# Altium schematic sheet [01] - RS232.SchDoc("[01] - RS232")
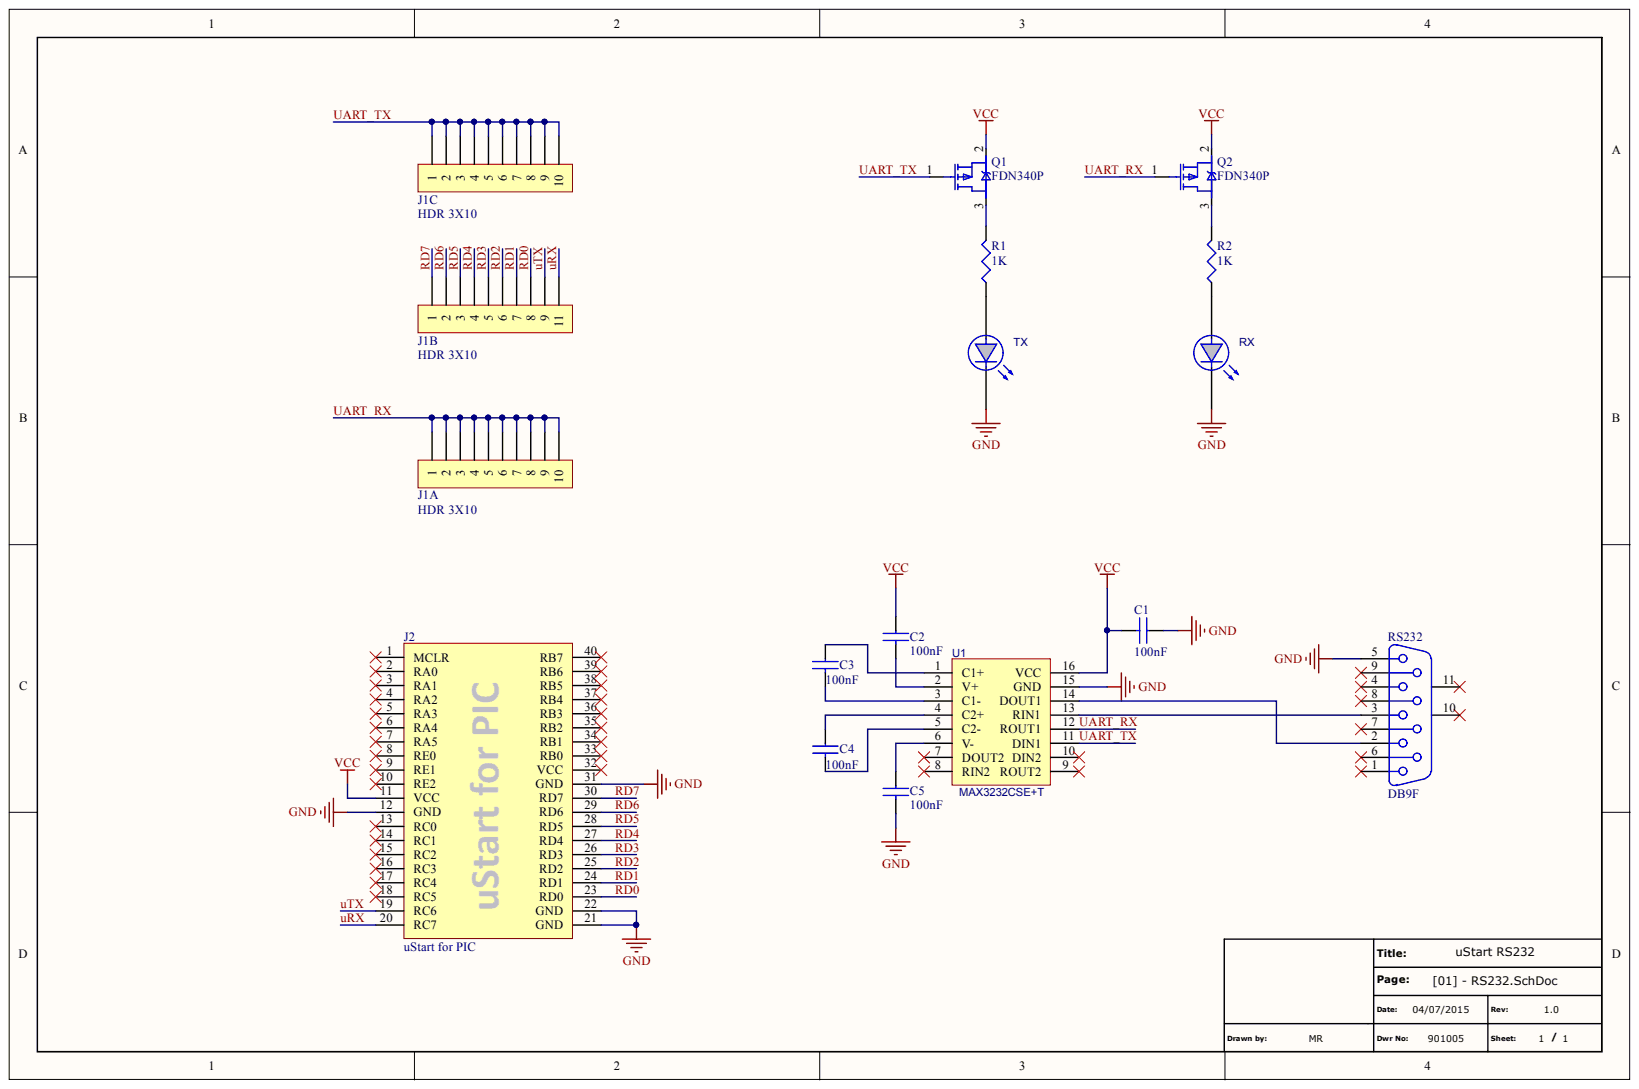
[diagram p0: [01] - RS232.SchDoc]

## Parts
C1  100nF  [C0603]
C2  100nF  [C0603]
C3  100nF  [C0603]
C4  100nF  [C0603]
C5  100nF  [C0603]
J1  HDR 3X10  [HDR 3X10 2.54MM]  (parts A,B,C)
J2  uStart for PIC  [SHIELD_uStart]  (value not drawn)
Q1  FDN340P  [SOT-23]
Q2  FDN340P  [SOT-23]
R1  1K  [R0805]
R2  1K  [R0805]
RS232  DB9F  [DB9_F_90]
RX  LEDSMD 0805  [LED_0805]  (value not drawn)
TX  LEDSMD 0805  [LED_0805]  (value not drawn)
U1  MAX3232CSE+T  [16-SOIC]

## Nets
GND: C1.1 C5.2 J2.12(GND) J2.21(GND) J2.22(GND) J2.31(GND) RS232.5 RX.2 TX.2 U1.15(GND)
VCC: C1.2 C2.1 J2.11(VCC) Q1.2 Q2.2 U1.16(VCC)
RD0: J1.18 J2.23(RD0)
RD1: J1.17 J2.24(RD1)
RD2: J1.16 J2.25(RD2)
RD3: J1.15 J2.26(RD3)
RD4: J1.14 J2.27(RD4)
RD5: J1.13 J2.28(RD5)
RD6: J1.12 J2.29(RD6)
RD7: J1.11 J2.30(RD7)
UART_RX: J1.1 J1.2 J1.3 J1.4 J1.5 J1.6 J1.7 J1.8 J1.9 J1.10 Q2.1 U1.12(ROUT1)
UART_TX: J1.21 J1.22 J1.23 J1.24 J1.25 J1.26 J1.27 J1.28 J1.29 J1.30 Q1.1 U1.11(DIN1)
URX: J1.20 J2.20(RC7)
UTX: J1.19 J2.19(RC6)
NetC2_2: C2.2 U1.2(V+)
NetC3_1: C3.1 U1.1(C1+)
NetC3_2: C3.2 U1.3(C1-)
NetC4_1: C4.1 U1.4(C2+)
NetC4_2: C4.2 U1.5(C2-)
NetC5_1: C5.1 U1.6(V-)
NetQ1_3: Q1.3 R1.2
NetQ2_3: Q2.3 R2.2
NetR1_1: R1.1 TX.1
NetR2_1: R2.1 RX.1
NetRS232_2: RS232.2 U1.14(DOUT1)
NetRS232_3: RS232.3 U1.13(RIN1)

Not connected: J2 1(MCLR) 2(RA0) 3(RA1) 4(RA2) 5(RA3) 6(RA4) 7(RA5) 8(RE0) 9(RE1) 10(RE2) 13(RC0) 14(RC1) 15(RC2) 16(RC3) 17(RC4) 18(RC5) 32(VCC) 33(RB0) 34(RB1) 35(RB2) 36(RB3) 37(RB4) 38(RB5) 39(RB6) 40(RB7); RS232 1 4 6 7 8 9 10 11; U1 7(DOUT2) 8(RIN2) 9(ROUT2) 10(DIN2)

## Library data of the parts
C1-C5 = C1608X7R1H104K080AA: CAP CER 0.1UF 50V 10% X7R 0603; Manufacturer: TDK Corporation; Capacitance: 0.1µF; Applications: General Purpose; Category: Capacitors; Component Kind: Standard; ComponentLink1Description: http://product.tdk.com/en/catalog/datasheets/mlcc_commercial_general_en.pdf; ComponentLink1URL: http://product.tdk.com/en/catalog/datasheets/mlcc_commercial_general_en.pdf; Features: -; Height - Seated (Max): -; Lead Spacing: -; Lead Style: -; Manufacturer Part Number: C1608X7R1H104K080AA; Mounting Type: Surface Mount, MLCC; Operating Temperature: -55°C ~ 125°C; Package / Case: 0603 (1608 Metric); Packaging: Cut Tape (CT); Pricing 1: 1=0.1, 10=0.044, 100=0.0202, 250=0.01652, 500=0.01414, 1000=0.0108 (USD); Ratings: -; RoHS: RoHS Compliant; Size / Dimension: 0.063" L x 0.031" W (1.60mm x 0.80mm); Stock 1: 1918800; Supplier 1: Digi-Key; Supplier Part Number 1: 445-1314-1-ND; Temperature Coefficient: X7R; Thickness (Max): 0.037" (0.95mm); Tolerance: ±10%; Voltage - Rated: 50V; Library Name: LIB MARCOS RIBEIRO.SchLib
J1 = HDR 3x10 2.54MM: PIN HEADER 3X10; Component Kind: Standard; Library Name: LIB MARCOS RIBEIRO.SchLib
J2 = uStart_shield: Component Kind: Standard; Library Name: LIB MARCOS RIBEIRO.SchLib
Q1 Q2 = FDN340P: MOSFET P-CH 20V 2A SSOT3; Component Kind: Standard; Library Name: LIB MARCOS RIBEIRO.SchLib
R1 R2 = ERJ-6GEYJ102V: RES 1K OHM 1/8W 5% 0805 SMD; Manufacturer: Panasonic Electronic Components; Power (Watts): 0.125W, 1/8W; Temperature Coefficient: ±200ppm/°C; Category: Resistors; Component Kind: Standard; ComponentLink1Description: http://industrial.panasonic.com/www-cgi/jvcr13pz.cgi?E+PZ+3+AOA0001+ERJ6GEYJ102V+7+WW; ComponentLink1URL: http://industrial.panasonic.com/www-cgi/jvcr13pz.cgi?E+PZ+3+AOA0001+ERJ6GEYJ102V+7+WW; Composition: Thick Film; Features: Automotive AEC-Q200; Height: 0.028" (0.70mm); Manufacturer Part Number: ERJ-6GEYJ102V; Number of Terminations: 2; Operating Temperature: -55°C ~ 155°C; Package / Case: 0805 (2012 Metric); Packaging: Cut Tape (CT); Pricing 1: 1=0.1, 50=0.0196, 100=0.0149, 250=0.01104, 500=0.00816, 1000=0.00544, 2500=0.00459 (USD); Resistance (Ohms): 1k; RoHS: RoHS Compliant; Size / Dimension: 0.079" L x 0.049" W (2.00mm x 1.25mm); Stock 1: 1497653; Supplier 1: Digi-Key; Supplier Device Package: 0805; Supplier Part Number 1: P1.0KACT-ND; Tolerance: ±5%; Library Name: LIB MARCOS RIBEIRO.SchLib
RS232 = CON-DB9-90GR-FEMEA: Component Kind: Standard; Description: CON-DB9-90GR-FEMEA; Library Name: LIB MARCOS RIBEIRO.SchLib
U1 = MAX3232CSE+T: IC TXRX RS-232 LP 16-SOIC; Manufacturer: Maxim Integrated; Data Rate: 235Kbps; Duplex: Full; Number of Drivers/Receivers: 2/2; Protocol: RS232; Receiver Hysteresis: 300mV; Voltage - Supply: 3 V ~ 5.5 V; Category: Integrated Circuits (ICs); Component Kind: Standard; ComponentLink1Description: http://datasheets.maximintegrated.com/en/ds/MAX3222-MAX3241.pdf; ComponentLink1URL: http://datasheets.maximintegrated.com/en/ds/MAX3222-MAX3241.pdf; Manufacturer Part Number 1: MAX3232CSE+T; Mounting Type: Surface Mount; Operating Temperature: 0°C ~ 70°C; Package / Case: 16-SOIC (0.154", 3.90mm Width); Packaging: Tape & Reel (TR); Pricing 1: 2500=2.68056 (USD); RoHS: RoHS Compliant; Stock 1: 0; Supplier 1: Digi-Key; Supplier Device Package: 16-SOIC; Supplier Part Number 1: MAX3232CSE+TTR-ND; Type: Transceiver; Library Name: LIB MARCOS RIBEIRO.SchLib
RX TX = LEDSMD 0805: Component Kind: Standard; Description: LEDSMD 0805; Library Name: LIB MARCOS RIBEIRO.SchLib
